# Altium schematic sheet M010_LQFP100.SchDoc
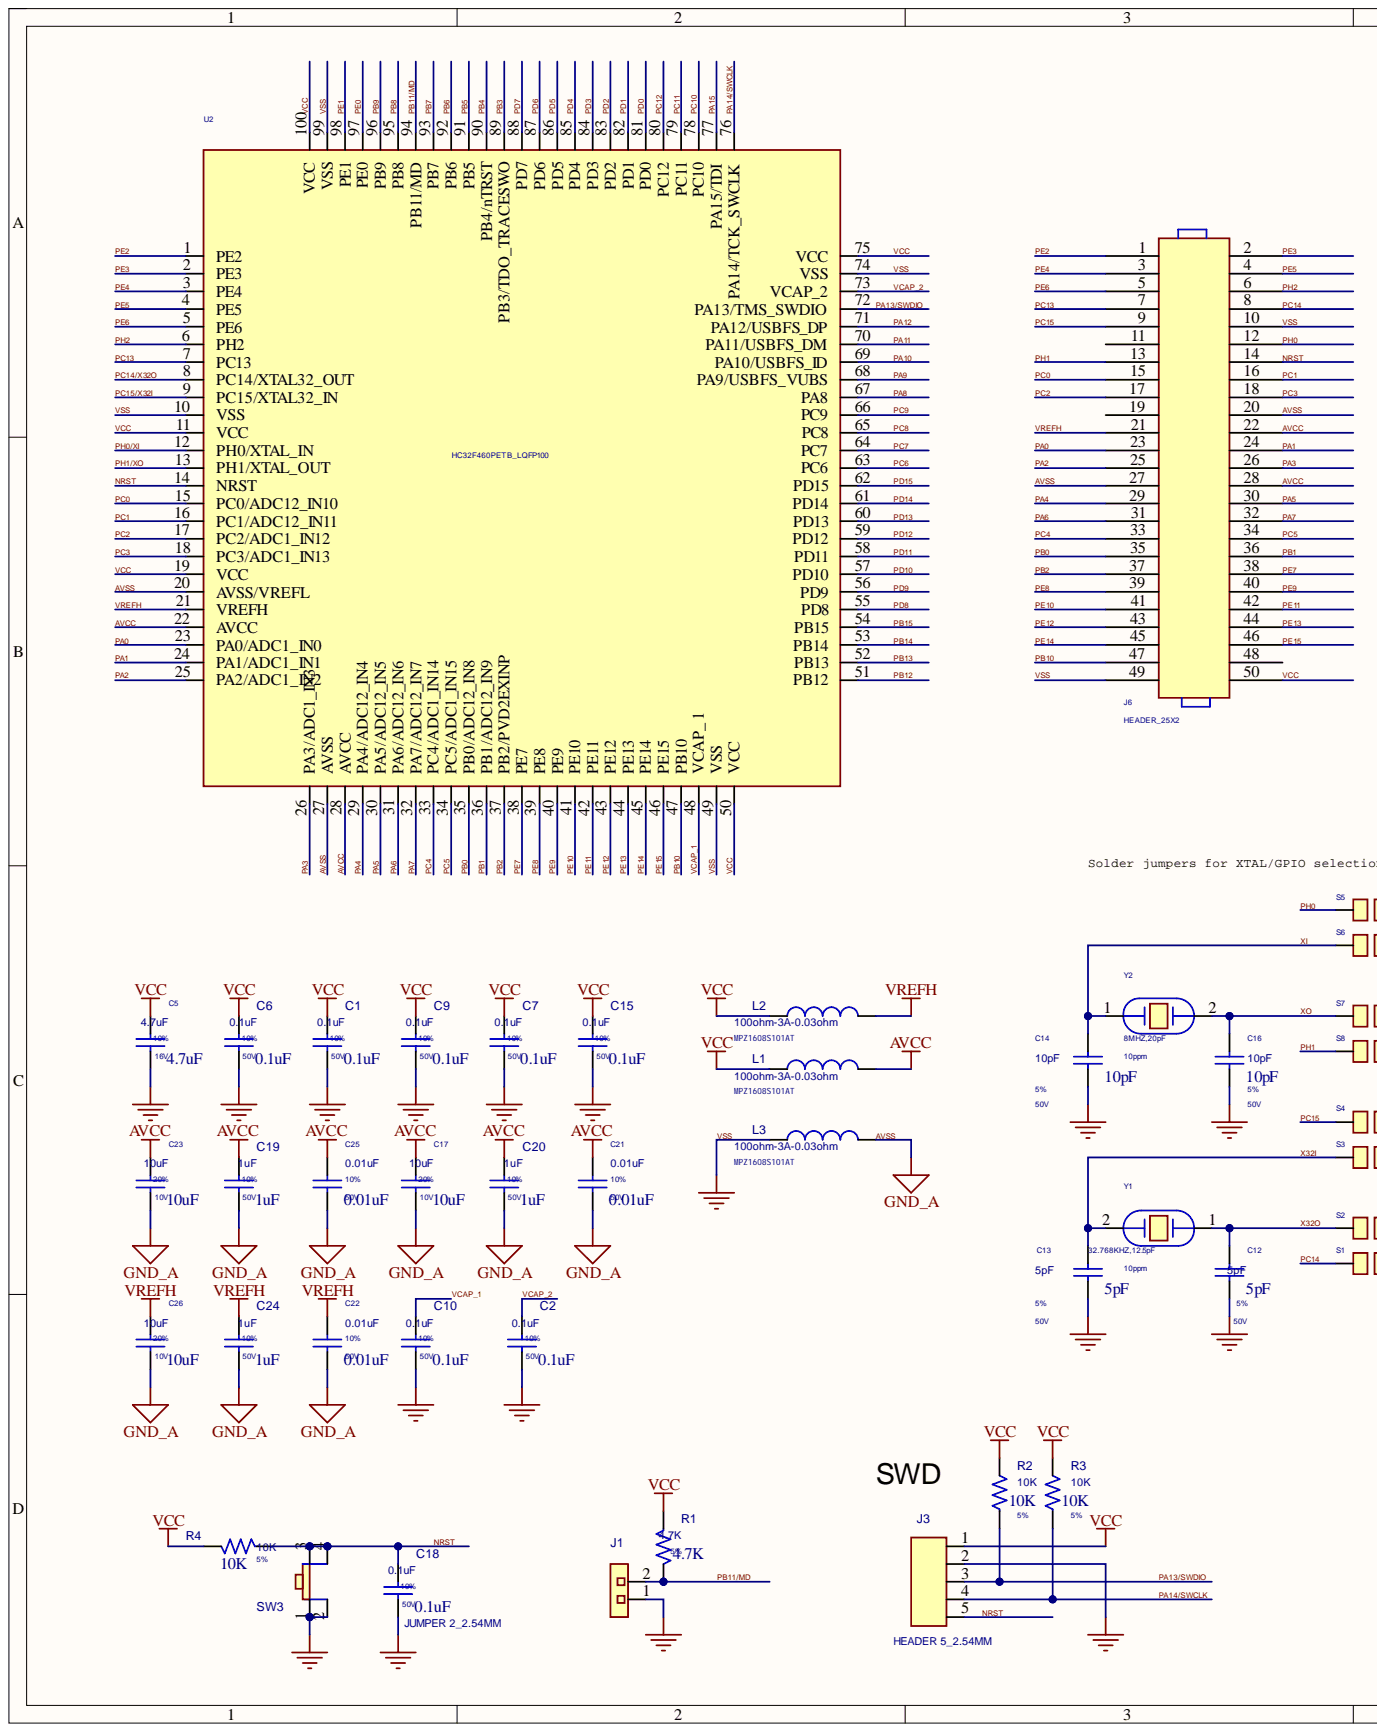
[diagram p0: M010_LQFP100.SchDoc, left]
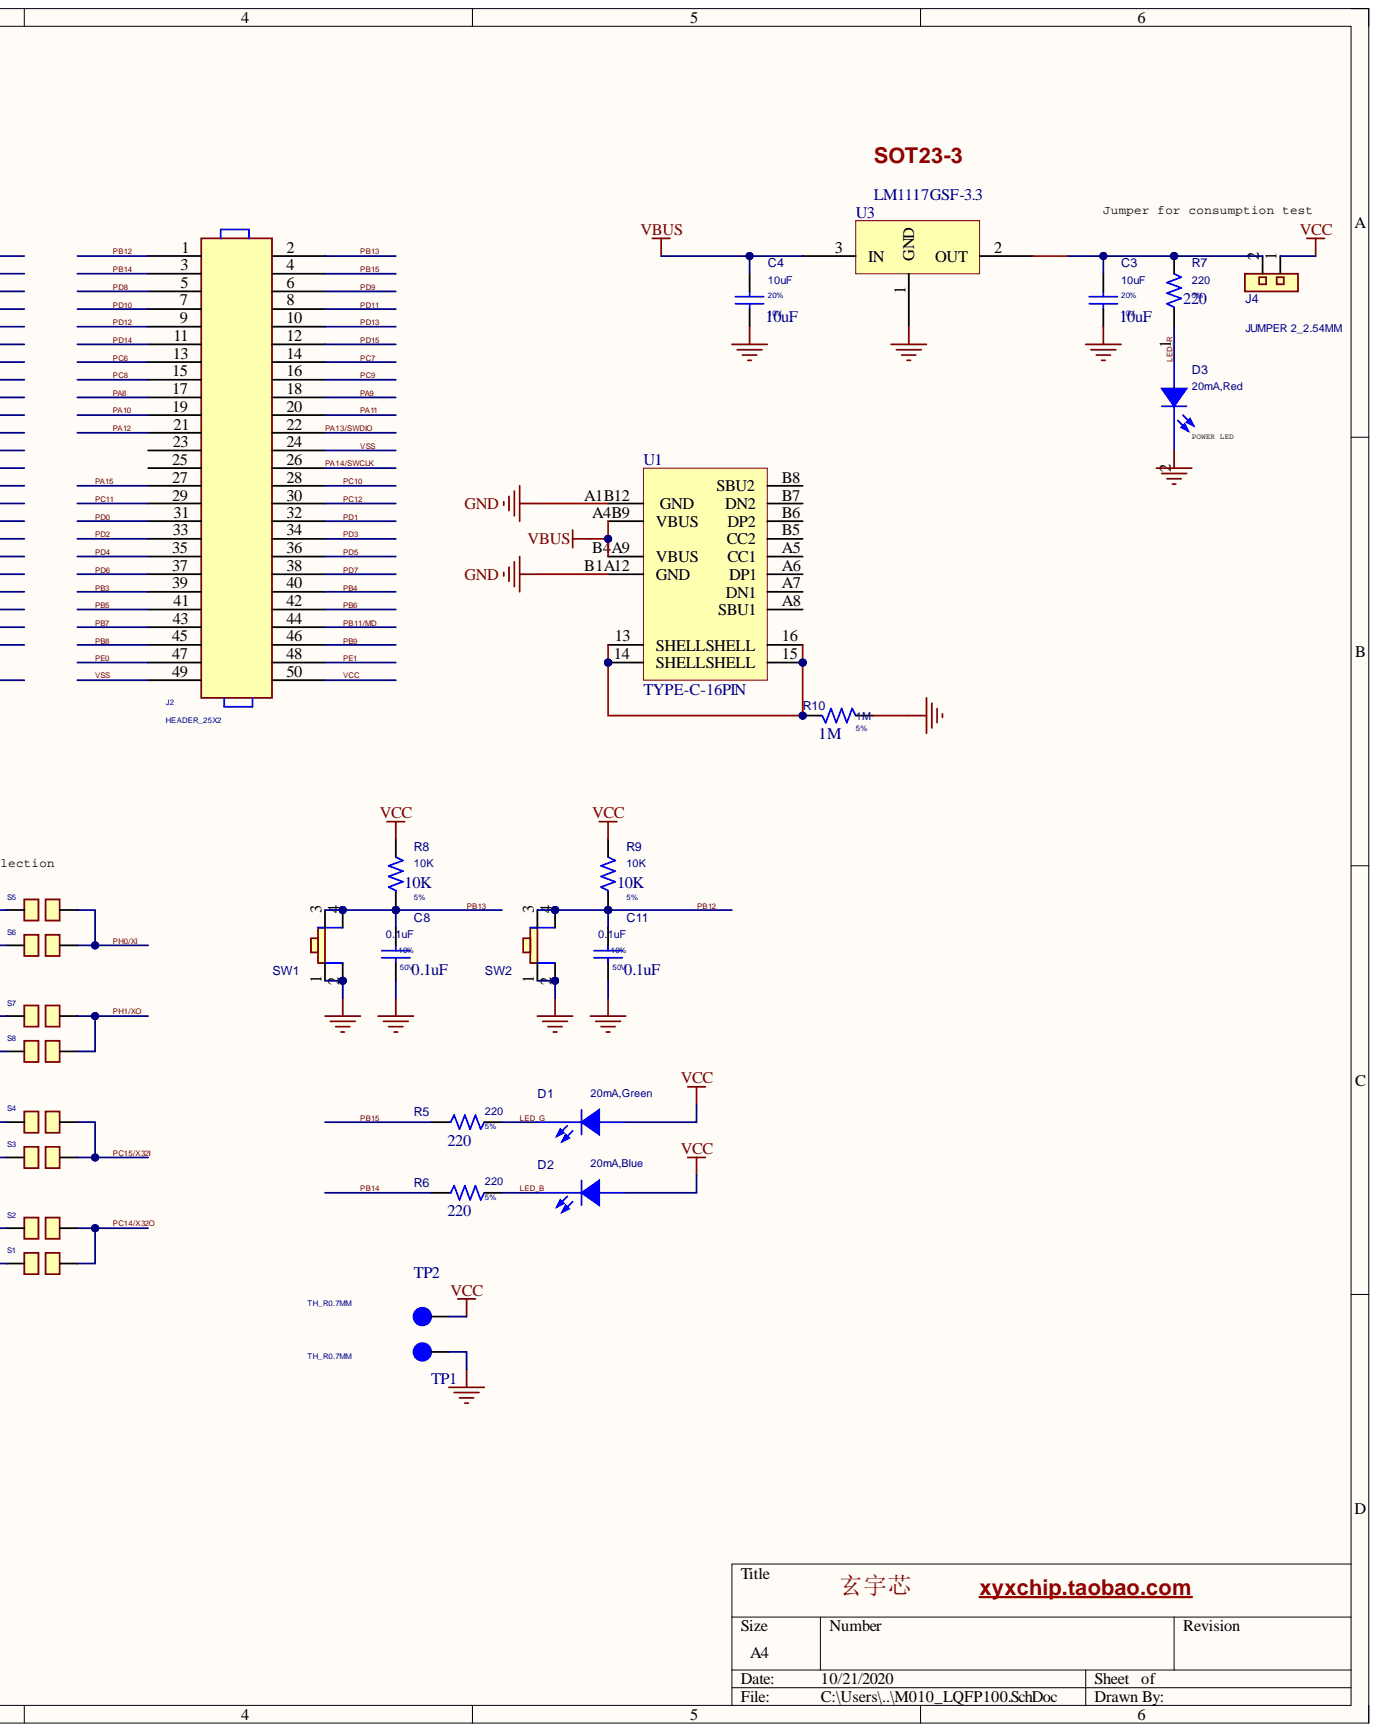
[diagram p1: M010_LQFP100.SchDoc, right]

## Parts
C1  0.1uF  TOLERANCE: 10%  VOLTAGE: 50V  [0603_C]
C2  0.1uF  TOLERANCE: 10%  VOLTAGE: 50V  [0603_C]
C3  10uF  TOLERANCE: 20%  VOLTAGE: 10V  [0603_C]
C4  10uF  TOLERANCE: 20%  VOLTAGE: 10V  [0603_C]
C5  4.7uF  TOLERANCE: 10%  VOLTAGE: 16V  [0603_C]
C6  0.1uF  TOLERANCE: 10%  VOLTAGE: 50V  [0603_C]
C7  0.1uF  TOLERANCE: 10%  VOLTAGE: 50V  [0603_C]
C8  0.1uF  TOLERANCE: 10%  VOLTAGE: 50V  [0603_C]
C9  0.1uF  TOLERANCE: 10%  VOLTAGE: 50V  [0603_C]
C10  0.1uF  TOLERANCE: 10%  VOLTAGE: 50V  [0603_C]
C11  0.1uF  TOLERANCE: 10%  VOLTAGE: 50V  [0603_C]
C12  5pF  TOLERANCE: 5%  VOLTAGE: 50V  [0603_C]
C13  5pF  TOLERANCE: 5%  VOLTAGE: 50V  [0603_C]
C14  10pF  TOLERANCE: 5%  VOLTAGE: 50V  [0603_C]
C15  0.1uF  TOLERANCE: 10%  VOLTAGE: 50V  [0603_C]
C16  10pF  TOLERANCE: 5%  VOLTAGE: 50V  [0603_C]
C17  10uF  TOLERANCE: 20%  VOLTAGE: 10V  [0603_C]
C18  0.1uF  TOLERANCE: 10%  VOLTAGE: 50V  [0603_C]
C19  1uF  TOLERANCE: 10%  VOLTAGE: 50V  [0603_C]
C20  1uF  TOLERANCE: 10%  VOLTAGE: 50V  [0603_C]
C21  0.01uF  TOLERANCE: 10%  VOLTAGE: 50V  [0603_C]
C22  0.01uF  TOLERANCE: 10%  VOLTAGE: 50V  [0603_C]
C23  10uF  TOLERANCE: 20%  VOLTAGE: 10V  [0603_C]
C24  1uF  TOLERANCE: 10%  VOLTAGE: 50V  [0603_C]
C25  0.01uF  TOLERANCE: 10%  VOLTAGE: 50V  [0603_C]
C26  10uF  TOLERANCE: 20%  VOLTAGE: 10V  [0603_C]
D1  20mA,Green  [LED0805-RD]
D2  20mA,Blue  [LED0805-RD]
D3  20mA,Red  [LED0805-RD]
J1  JUMPER 2_2.54MM  [HDR-TH_2P-P2.54-V]
J2  HEADER_25X2  [HDR-TH_2X25P-P2.54-V]
J3  HEADER 5_2.54MM  [HDR-TH_5P-P2.54-V]
J4  JUMPER 2_2.54MM  [HDR-TH_2P-P2.54-V]
J6  HEADER_25X2  [HDR-TH_2X25P-P2.54-V]
L1  100ohm-3A-0.03ohm  MFG_PN01: MPZ1608S101AT  [0603_L]
L2  100ohm-3A-0.03ohm  MFG_PN01: MPZ1608S101AT  [0603_L]
L3  100ohm-3A-0.03ohm  MFG_PN01: MPZ1608S101AT  [0603_L]
R1  4.7K  TOLERANCE: 5%  [0603_R]
R2  10K  TOLERANCE: 5%  [0603_R]
R3  10K  TOLERANCE: 5%  [0603_R]
R4  10K  TOLERANCE: 5%  [0603_R]
R5  220  TOLERANCE: 5%  [0603_R]
R6  220  TOLERANCE: 5%  [0603_R]
R7  220  TOLERANCE: 5%  [0603_R]
R8  10K  TOLERANCE: 5%  [0603_R]
R9  10K  TOLERANCE: 5%  [0603_R]
R10  1M  TOLERANCE: 5%  [0603_R]
S1  SOLDER_JUMPER  [0603_R]  (value not drawn)
S2  SOLDER_JUMPER  [0603_R]  (value not drawn)
S3  SOLDER_JUMPER  [0603_R]  (value not drawn)
S4  SOLDER_JUMPER  [0603_R]  (value not drawn)
S5  SOLDER_JUMPER  [0603_R]  (value not drawn)
S6  SOLDER_JUMPER  [0603_R]  (value not drawn)
S7  SOLDER_JUMPER  [0603_R]  (value not drawn)
S8  SOLDER_JUMPER  [0603_R]  (value not drawn)
SW1  PUSHBOTTON  [KEY-SMD_4P-L6.0-W6.0-P4.50-LS9.5]  (value not drawn)
SW2  PUSHBOTTON  [KEY-SMD_4P-L6.0-W6.0-P4.50-LS9.5]  (value not drawn)
SW3  PUSHBOTTON  [KEY-SMD_4P-L6.0-W6.0-P4.50-LS9.5]  (value not drawn)
TP1  TH_R0.7MM  [HOLE1.4-2]
TP2  TH_R0.7MM  [HOLE1.4-2]
U1  TYPE-C-16PIN  [USB-C-SMD_TYPEC-304-BCP16]
U2  HC32F460PETB_LQFP100  [LQFP100(14*14)]
U3  LM1117GSF-3.3  [SOT-23-3]
Y1  32.768KHZ,12.5pF  Tolerance: 10ppm  [XTAL32768]
Y2  8MHZ,20pF  Tolerance: 10ppm  [OSC-SMD_L5.0-W3.2]

## Nets
VBUS: C4.1 U1.A4B9(VBUS) U1.B4A9(VBUS) U3.3
AVCC: C17.2 C19.1 C20.1 C21.1 C23.2 C25.1 J6.22 J6.28 L1.1 U2.22(AVCC) U2.28(AVCC)
AVSS: C17.1 C19.2 C20.2 C21.2 C22.2 C23.1 C24.2 C25.2 C26.1 J6.20 J6.27 L3.1 U2.20(AVSS/VREFL) U2.27(AVSS)
LED_B: D2.2 R6.2
LED_G: D1.2 R5.2
LED_R: D3.1 R7.1
NRST: C18.1 J3.5 J6.14 R4.1 SW3.3 SW3.4 U2.14(NRST)
PA0: J6.23 U2.23(PA0/ADC1_IN0)
PA1: J6.24 U2.24(PA1/ADC1_IN1)
PA2: J6.25 U2.25(PA2/ADC1_IN2)
PA3: J6.26 U2.26(PA3/ADC1_IN3)
PA4: J6.29 U2.29(PA4/ADC12_IN4)
PA5: J6.30 U2.30(PA5/ADC12_IN5)
PA6: J6.31 U2.31(PA6/ADC12_IN6)
PA7: J6.32 U2.32(PA7/ADC12_IN7)
PA8: J2.17 U2.67(PA8)
PA9: J2.18 U2.68(PA9/USBFS_VUBS)
PA10: J2.19 U2.69(PA10/USBFS_ID)
PA11: J2.20 U2.70(PA11/USBFS_DM)
PA12: J2.21 U2.71(PA12/USBFS_DP)
PA13/SWDIO: J2.22 J3.3 R2.1 U2.72(PA13/TMS_SWDIO)
PA14/SWCLK: J2.26 J3.4 R3.1 U2.76(PA14/TCK_SWCLK)
PA15: J2.27 U2.77(PA15/TDI)
PB0: J6.35 U2.35(PB0/ADC12_IN8)
PB1: J6.36 U2.36(PB1/ADC12_IN9)
PB2: J6.37 U2.37(PB2/PVD2EXINP)
PB3: J2.39 U2.89(PB3/TDO_TRACESWO)
PB4: J2.40 U2.90(PB4/nTRST)
PB5: J2.41 U2.91(PB5)
PB6: J2.42 U2.92(PB6)
PB7: J2.43 U2.93(PB7)
PB8: J2.45 U2.95(PB8)
PB9: J2.46 U2.96(PB9)
PB10: J6.47 U2.47(PB10)
PB11/MD: J1.2 J2.44 R1.2 U2.94(PB11/MD)
PB12: C11.1 J2.1 R9.1 SW2.3 SW2.4 U2.51(PB12)
PB13: C8.1 J2.2 R8.1 SW1.3 SW1.4 U2.52(PB13)
PB14: J2.3 R6.1 U2.53(PB14)
PB15: J2.4 R5.1 U2.54(PB15)
PC0: J6.15 U2.15(PC0/ADC12_IN10)
PC1: J6.16 U2.16(PC1/ADC12_IN11)
PC2: J6.17 U2.17(PC2/ADC1_IN12)
PC3: J6.18 U2.18(PC3/ADC1_IN13)
PC4: J6.33 U2.33(PC4/ADC1_IN14)
PC5: J6.34 U2.34(PC5/ADC1_IN15)
PC6: J2.13 U2.63(PC6)
PC7: J2.14 U2.64(PC7)
PC8: J2.15 U2.65(PC8)
PC9: J2.16 U2.66(PC9)
PC10: J2.28 U2.78(PC10)
PC11: J2.29 U2.79(PC11)
PC12: J2.30 U2.80(PC12)
PC13: J6.7 U2.7(PC13)
PC14: J6.8 S1.1
PC14/X32O: S1.2 S2.2 U2.8(PC14/XTAL32_OUT)
PC15: J6.9 S4.1
PC15/X32I: S3.2 S4.2 U2.9(PC15/XTAL32_IN)
PD0: J2.31 U2.81(PD0)
PD1: J2.32 U2.82(PD1)
PD2: J2.33 U2.83(PD2)
PD3: J2.34 U2.84(PD3)
PD4: J2.35 U2.85(PD4)
PD5: J2.36 U2.86(PD5)
PD6: J2.37 U2.87(PD6)
PD7: J2.38 U2.88(PD7)
PD8: J2.5 U2.55(PD8)
PD9: J2.6 U2.56(PD9)
PD10: J2.7 U2.57(PD10)
PD11: J2.8 U2.58(PD11)
PD12: J2.9 U2.59(PD12)
PD13: J2.10 U2.60(PD13)
PD14: J2.11 U2.61(PD14)
PD15: J2.12 U2.62(PD15)
PE0: J2.47 U2.97(PE0)
PE1: J2.48 U2.98(PE1)
PE2: J6.1 U2.1(PE2)
PE3: J6.2 U2.2(PE3)
PE4: J6.3 U2.3(PE4)
PE5: J6.4 U2.4(PE5)
PE6: J6.5 U2.5(PE6)
PE7: J6.38 U2.38(PE7)
PE8: J6.39 U2.39(PE8)
PE9: J6.40 U2.40(PE9)
PE10: J6.41 U2.41(PE10)
PE11: J6.42 U2.42(PE11)
PE12: J6.43 U2.43(PE12)
PE13: J6.44 U2.44(PE13)
PE14: J6.45 U2.45(PE14)
PE15: J6.46 U2.46(PE15)
PH0: J6.12 S5.1
PH0/XI: S5.2 S6.2 U2.12(PH0/XTAL_IN)
PH1: J6.13 S8.1
PH1/XO: S7.2 S8.2 U2.13(PH1/XTAL_OUT)
PH2: J6.6 U2.6(PH2)
VCAP_1: C10.2 U2.48(VCAP__1)
VCAP_2: C2.2 U2.73(VCAP_2)
VCC: C1.1 C5.2 C6.1 C7.1 C9.1 C15.1 D1.1 D2.1 J2.50 J3.1 J4.1 J6.50 L1.2 L2.2 R1.1 R2.2 R3.2 R4.2 R8.2 R9.2 TP2.1 U2.11(VCC) U2.19(VCC) U2.50(VCC) U2.75(VCC) U2.100(VCC)
VREFH: C22.1 C24.1 C26.2 J6.21 L2.1 U2.21(VREFH)
VSS: C1.2 C2.1 C3.2 C4.2 C5.1 C6.2 C7.2 C8.2 C9.2 C10.1 C11.2 C12.1 C13.1 C14.1 C15.2 C16.1 C18.2 D3.2 J1.1 J2.24 J2.49 J3.2 J6.10 J6.49 L3.2 R10.2 SW1.1 SW1.2 SW2.1 SW2.2 SW3.1 SW3.2 TP1.1 U1.A1B12(GND) U1.B1A12(GND) U2.10(VSS) U2.49(VSS) U2.74(VSS) U2.99(VSS) U3.1
X32I: C13.2 S3.1 Y1.2
X32O: C12.2 S2.1 Y1.1
XI: C14.2 S6.1 Y2.1
XO: C16.2 S7.1 Y2.2
NetC3_1: C3.1 J4.2 R7.2 U3.2
NetR10_1: R10.1 U1.13(SHELL) U1.14(SHELL) U1.15(SHELL) U1.16(SHELL)

Not connected: J2 23 25; J6 11 19 48; U1 A5(CC1) A6(DP1) A7(DN1) A8(SBU1) B5(CC2) B6(DP2) B7(DN2) B8(SBU2)

## Library data of the parts
C1 C2 C6-C11 C15 C18 = c: CAP CER 1.0UF 10V 10% X5R 0402; MFG_NAME01: YAGEO; MFG_PN01: CC0603KRX7R9BB104; PSpiceTemplate: C^@REFDES %1 %2 ?TOLERANCE|C^@REFDES| @VALUE ?IC/IC=@IC/ ?TC/TC=@TC/ ?TOLERANCE|\n.model C^@REFDES CAP C=1 DEV=@TOLERANCE%|; SIGNAL_MODEL: DEFAULT_CAPACITOR_1e+06pF_2_1; Component Kind: Standard; HEIGHT: 0.6MM; Simulation: c; Value: 0.1uF
C3 C4 = c: CAP CER 10UF 10V 10% X5R 0603; MFG_NAME01: YAGEO; MFG_PN01: CC0603MRX5R6BB106; PSpiceTemplate: C^@REFDES %1 %2 ?TOLERANCE|C^@REFDES| @VALUE ?IC/IC=@IC/ ?TC/TC=@TC/ ?TOLERANCE|\n.model C^@REFDES CAP C=1 DEV=@TOLERANCE%|; SIGNAL_MODEL: DEFAULT_CAPACITOR_4.7e+06pF_2_1; Component Kind: Standard; HEIGHT: 1.016MM; Simulation: c; Value: 10uF; WVDC: 10V
C5 = c: CAP CER 1.0UF 10V 10% X5R 0402; AGILE_PN: 150-75772; CAD_APPROVED: Yes; FPLIST: CC0402_25,CC0402_HD,0402_CC; MFG_NAME01: MURATA; MFG_PN01: GRM188R61C475KE11; PSpiceTemplate: C^@REFDES %1 %2 ?TOLERANCE|C^@REFDES| @VALUE ?IC/IC=@IC/ ?TC/TC=@TC/ ?TOLERANCE|\n.model C^@REFDES CAP C=1 DEV=@TOLERANCE%|; SIGNAL_MODEL: DEFAULT_CAPACITOR_1e+06pF_2_1; Component Kind: Standard; HEIGHT: 0.6MM; ROHS: Yes; Simulation: c; Value: 4.7uF; WVDC: 16V
C12 C13 = c: CAP CER 30PF 50V 5% C0G 0402; AGILE_PN: 150-75266; CAD_APPROVED: Yes; FPLIST: CC0402_25,CC0402_HD,0402_CC; MFG_NAME01: MURATA; MFG_PN01: GCM1885C1H5R0CA16D; PRIORITY_PN: 021-00234; PSpiceTemplate: C^@REFDES %1 %2 ?TOLERANCE|C^@REFDES| @VALUE ?IC/IC=@IC/ ?TC/TC=@TC/ ?TOLERANCE|\n.model C^@REFDES CAP C=1 DEV=@TOLERANCE%|; SIGNAL_MODEL: DEFAULT_CAPACITOR_22pF_2_1; Component Kind: Standard; HEIGHT: 0.635MM; ROHS: Yes; Simulation: c; Value: 5pF
C14 C16 = c: CAP CER 30PF 50V 5% C0G 0402; AGILE_PN: 150-75266; CAD_APPROVED: Yes; FPLIST: CC0402_25,CC0402_HD,0402_CC; MFG_NAME01: MURATA; MFG_PN01: GRM1882C1H100JA01D; PRIORITY_PN: 021-00234; PSpiceTemplate: C^@REFDES %1 %2 ?TOLERANCE|C^@REFDES| @VALUE ?IC/IC=@IC/ ?TC/TC=@TC/ ?TOLERANCE|\n.model C^@REFDES CAP C=1 DEV=@TOLERANCE%|; SIGNAL_MODEL: DEFAULT_CAPACITOR_22pF_2_1; Component Kind: Standard; HEIGHT: 0.635MM; ROHS: Yes; Simulation: c; Value: 10pF
C17 C23 C26 = c: CAP CER 1.0UF 10V 10% X5R 0402; AGILE_PN: 150-75772; CAD_APPROVED: Yes; FPLIST: CC0402_25,CC0402_HD,0402_CC; MFG_NAME01: YAGEO; MFG_PN01: CC0603MRX5R6BB106; PSpiceTemplate: C^@REFDES %1 %2 ?TOLERANCE|C^@REFDES| @VALUE ?IC/IC=@IC/ ?TC/TC=@TC/ ?TOLERANCE|\n.model C^@REFDES CAP C=1 DEV=@TOLERANCE%|; SIGNAL_MODEL: DEFAULT_CAPACITOR_1e+06pF_2_1; WVDC: 16V; Component Kind: Standard; HEIGHT: 0.6MM; ROHS: Yes; Simulation: c; Value: 10uF
C19 C20 C24 = c: CAP CER 1.0UF 10V 10% X5R 0402; MFG_NAME01: MURATA; MFG_PN01: GRM188R61H105KAALD; PSpiceTemplate: C^@REFDES %1 %2 ?TOLERANCE|C^@REFDES| @VALUE ?IC/IC=@IC/ ?TC/TC=@TC/ ?TOLERANCE|\n.model C^@REFDES CAP C=1 DEV=@TOLERANCE%|; SIGNAL_MODEL: DEFAULT_CAPACITOR_1e+06pF_2_1; Component Kind: Standard; HEIGHT: 0.6MM; Simulation: c; Value: 1uF
C21 C22 C25 = c: CAP CER 2.2UF 10V 10% X5R 0603; AGILE_PN: 150-75487; CAD_APPROVED: Yes; MFG_NAME01: MURATA; MFG_PN01: GRM188R71H103KA01D; PSpiceTemplate: C^@REFDES %1 %2 ?TOLERANCE|C^@REFDES| @VALUE ?IC/IC=@IC/ ?TC/TC=@TC/ ?TOLERANCE|\n.model C^@REFDES CAP C=1 DEV=@TOLERANCE%|; SIGNAL_MODEL: DEFAULT_CAPACITOR_4.7e+06pF_2_1; Component Kind: Standard; HEIGHT: 1.016MM; ROHS: Yes; Simulation: c; Value: 0.01uF
D1 = LED_LTST_C150GKT: CLASS: DISCRETE; MFG_NAME01: EVERLIGHT; MFG_PN01: 19-217/GHC-YR1S2/3T; TN: 257-0020-000; Component Kind: Standard
D2 = LED_LTST_C150GKT: CLASS: DISCRETE; MFG_NAME01: EVERLIGHT; MFG_PN01: 19-217/BHC-ZL1M2RY/3T; TN: 257-0020-000; Component Kind: Standard
D3 = LED_LTST_C150GKT: CLASS: DISCRETE; MFG_NAME01: EVERLIGHT; MFG_PN01: 19-217/R6C-AL1M2VY/3T; TN: 257-0020-000; Component Kind: Standard
J1 J4 = 2H_Header_2x1: MfrNum: PH1-230/120-021; PartName: HEADER2Pin; TIIntPartnumber: 2H0000; Cost10K: 0.252; Cost100: 0.016; DescriptiveText: Single Line Header 2pin Tin 0.1in pitch; MFG_NAME01: OnShoreTechnology; MFG_PN01: 2.54mm,1*2Pin,直插排针; Vendor: Digikey; Component Kind: Standard; Mfr: OnShoreTechnology
J3 = 2H_Header_5x1: Mfr: Sullins; MfrNum: PEC05SAAN; PartName: HEADER5Pin; TIIntPartnumber: 2H0018; Cost10K: 0.294; Cost100: 0.321; DescriptiveText: Single Line Header 5pin Tin 0.1in pitch; MFG_NAME01: xxx; MFG_PN01: 2.54mm,1*5Pin,直插排针; Vendor: Digikey; Component Kind: Standard
L1-L3 = INDUCTOR_L: MFG_NAME01: TDK; Component Kind: Standard
R1 = r: RES MF 10.0K 1/16W 1% 0402; MFG_NAME01: YAGEO; MFG_PN01: RC0603JR-074K7L; Power: 1/10W; PSpiceTemplate: R^@REFDES %1 %2 ?TOLERANCE|R^@REFDES| @VALUE  ?TOLERANCE|\n.model r^@REFDES RES R=1 DEV=@TOLERANCE%|; SIGNAL_MODEL: DEFAULT_RESISTOR_10000OHM_2_1; Component Kind: Standard; Simulation: r; Value: 4.7K
R2 R3 R8 R9 = r: MFG_NAME01: YAGEO; MFG_PN01: RC0603JR-0710KL; Power: 1/10W; PSpiceTemplate: R^@REFDES %1 %2 ?TOLERANCE|R^@REFDES| @VALUE  ?TOLERANCE|\n.model r^@REFDES RES R=1 DEV=@TOLERANCE%|; Component Kind: Standard; Simulation: r; Value: 10K
R4 = r: RES MF 100K 1/16W 5% 0402; MFG_NAME01: YAGEO; MFG_PN01: RC0603JR-0710KL; Power: 1/10W; PSpiceTemplate: R^@REFDES %1 %2 ?TOLERANCE|R^@REFDES| @VALUE  ?TOLERANCE|\n.model r^@REFDES RES R=1 DEV=@TOLERANCE%|; SIGNAL_MODEL: DEFAULT_RESISTOR_10000OHM_2_1; Component Kind: Standard; Simulation: r; Value: 10K
R5-R7 = r: RES MF 220 1/16W 1% 0402; AGILE_PN: 470-75416; CAD_APPROVED: Yes; FPLIST: RC0402_25,RC0402_HD,0402_CC; MFG_NAME01: YAGEO; MFG_PN01: RC0603JR-07220RL; Power: 1/10W; PSpiceTemplate: R^@REFDES %1 %2 ?TOLERANCE|R^@REFDES| @VALUE  ?TOLERANCE|\n.model r^@REFDES RES R=1 DEV=@TOLERANCE%|; SIGNAL_MODEL: DEFAULT_RESISTOR_10000OHM_2_1; Component Kind: Standard; ROHS: Yes; Simulation: r; Value: 220
R10 = r: RES MF 10.0K 1/16W 1% 0402; MFG_NAME01: YAGEO; MFG_PN01: RC0603JR-071ML; Power: 1/10W; PSpiceTemplate: R^@REFDES %1 %2 ?TOLERANCE|R^@REFDES| @VALUE  ?TOLERANCE|\n.model r^@REFDES RES R=1 DEV=@TOLERANCE%|; SIGNAL_MODEL: DEFAULT_RESISTOR_10000OHM_2_1; Component Kind: Standard; Simulation: r; Value: 1M
S1-S8 = solder_jumper: Silkscreen: <Silkscreen>; Voltage: <Voltage>; Component Kind: Standard; Package: <Package>
SW1-SW3 = 2W_Switch_Buttonsmd: Mfr: Omron; MfrNum: B3SL-1022P; PartName: SwitchPushMomTactilesmd; TIIntPartnumber: 2W0018; Cost10K: 0.331; Cost100: 0.340; DescriptiveText: Switch Push Button tactile; MFG_NAME01: ALPS; MFG_PN01: SKRPABE010; Vendor: Digikey; Component Kind: Standard
TP1 TP2 = 2H_TestPointThroughHoleR28: Mfr: Keystone Electronics; MfrNum: 5002; TIIntPartnumber: 2H0026; Cost10K: 0.10; Cost100: 0.163; DescriptiveText: Testpoint Miniature Through Hole; Vendor: Digikey; Component Kind: Standard; PartName: TestPointThroughHole
U2 = M010_LQFP100: Component Kind: Standard
U3 = 7812: Component Kind: Standard; Library Name: YZJ-SCH库-0328.SchLib
Y1 = XTAL: XTAL 32.768KHZ 12.5PF 20PPM 3.2X1.5MM SMT; AGILE_PN: 230-77653; CAD_APPROVED: Yes; CLASS: IC; MFG_NAME01: YXC; MFG_PN01: X206032768KGB2SC; Component Kind: Standard; HEIGHT: 0.9MM; ROHS: Yes
Y2 = XTAL: XTAL 32.768KHZ 12.5PF 20PPM 3.2X1.5MM SMT; AGILE_PN: 230-77653; CAD_APPROVED: Yes; CLASS: IC; MFG_NAME01: YXC; MFG_PN01: X49SD8MSB2SC; Component Kind: Standard; HEIGHT: 0.9MM; ROHS: Yes
J2 J6 = HEADER_25X2: Component Kind: Standard
U1 = TYPE-C-16PIN: Component Kind: Standard; Library Name: Schlib1.SchLib
